# Altium schematic sheet EVSEInterface&ChargerRelay.SchDoc(EVSEInterface&ChargerRelay)
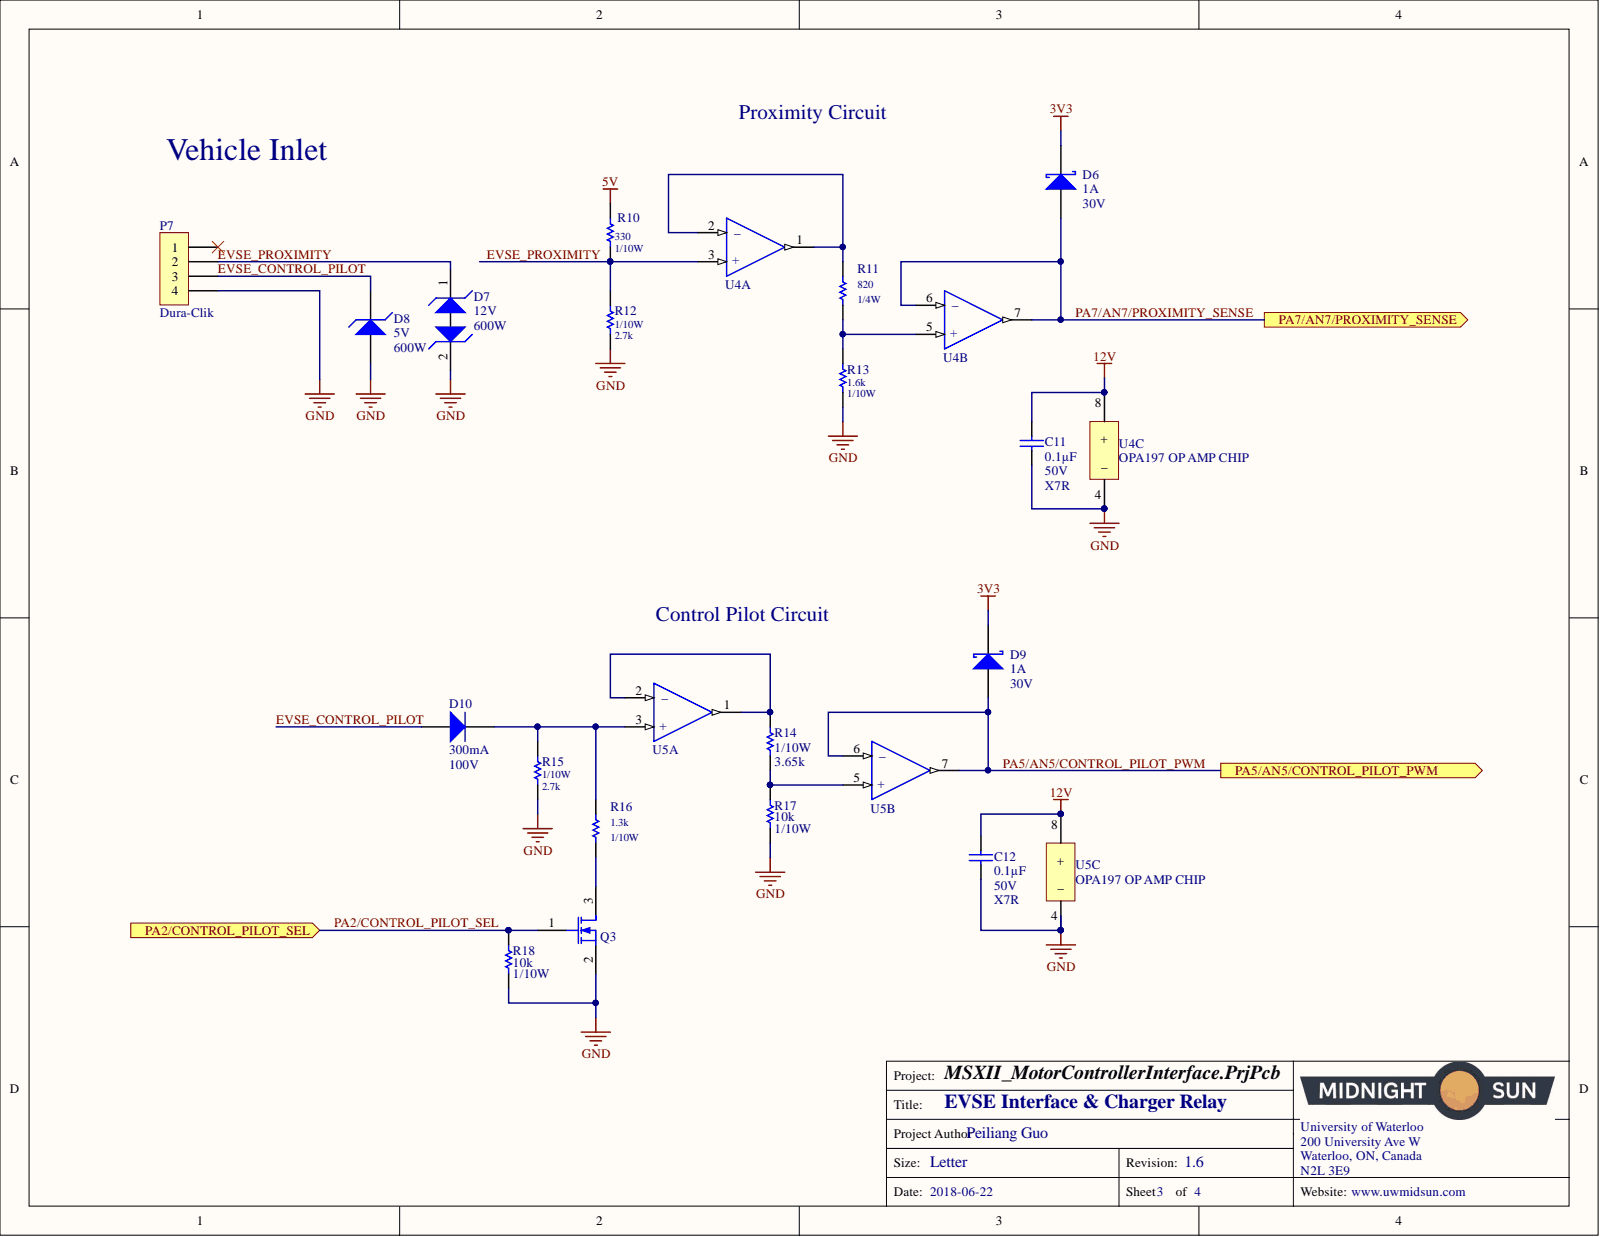
[diagram p0: EVSEInterface&ChargerRelay.SchDoc]

## Parts
C11  Voltage: 50V  Temperature Coefficient: X7R  Capacitance: 0.1µF  [CAP, 0603]  (hidden comment: CAP CER 0.1UF 50V 10% X7R 0603)
C12  Voltage: 50V  Temperature Coefficient: X7R  Capacitance: 0.1µF  [CAP, 0603]  (hidden comment: CAP CER 0.1UF 50V 10% X7R 0603)
D6  Voltage - DC Reverse (Vr) (Max): 30V  Current - Average Rectified (Io): 1A  [DIODE SCHOTTKY 30V 1A POWERDI123]  (hidden comment: DIODE SCHOTTKY 30V 1A POWERDI123)
D7  Voltage - Reverse Standoff (Typ): 12V  Power - Peak Pulse: 600W  [DO-214AA Diode]  (hidden comment: DIODE TVS 12VWM 19.6VC DO-214AA (SMB))
D8  Voltage - Reverse Standoff (Typ): 5V  Power - Peak Pulse: 600W  [DO-214AA Diode]  (hidden comment: DIODE TVS 5VWM 9.1VC DO-214AA (SMB))
D9  Voltage - DC Reverse (Vr) (Max): 30V  Current - Average Rectified (Io): 1A  [DIODE SCHOTTKY 30V 1A POWERDI123]  (hidden comment: DIODE SCHOTTKY 30V 1A POWERDI123)
D10  Max Repetitive Reverse Voltage (Vrrm): 100V  Forward Current: 300mA  [DIODE GEN PURP 100V 300MA SOD123]  (hidden comment: DIODE GEN PURP 100V 300MA SOD123)
P7  Dura-Clik  [CONN, 4POS DURA-CLIK VERT]
Q3  MOSFET N-CH 30V 6.2A 0.9W SOT-23  [MOSFET N-CH 30V 6.2A 0.9W SOT-23]  (value not drawn)
R10  Power: 1/10W  [RES, 0603]  (hidden comment: RES 330 OHM 1% 1/10W 0603)
R11  Power: 1/4W  [RES, 0603]  (hidden comment: RES 820 OHM 5% 1/4W 0603)
R12  Resistance: 2.7k  Power: 1/10W  [RES, 0603]  (hidden comment: RES 2.7K OHM 1% 1/10W 0603)
R13  Resistance: 1.6k  Power Rating: 1/10W  [RES, 0603]  (hidden comment: RES 1.6K OHM 1% 1/10W 0603)
R14  Resistance: 3.65k  Power Rating: 1/10W  [RES, 0603]  (hidden comment: RES 3.65KOHM 0.1% 1/10W 0603)
R15  Resistance: 2.7k  Power: 1/10W  [RES, 0603]  (hidden comment: RES 2.7K OHM 1% 1/10W 0603)
R16  Resistance: 1.3k  Power Rating: 1/10W  [RES, 0603]  (hidden comment: RES 1.3K OHM 1% 1/10W 0603)
R17  Resistance: 10k  Power: 1/10W  [RES, 0603]  (hidden comment: RES 10K OHM 1% 1/10W 0603)
R18  Resistance: 10k  Power: 1/10W  [RES, 0603]  (hidden comment: RES 10K OHM 1% 1/10W 0603)
U4  IC OP AMP DUAL GP RR 10MHZ 8-VSSOP  [IC OP AMP GP RR 10MHZ 8-VSSOP]  (value not drawn)  (parts A,B,C)
U5  IC OP AMP DUAL GP RR 10MHZ 8-VSSOP  [IC OP AMP GP RR 10MHZ 8-VSSOP]  (value not drawn)  (parts A,B,C)

## Ports
PA2/CONTROL_PILOT_SEL, PA5/AN5/CONTROL_PILOT_PWM, PA7/AN7/PROXIMITY_SENSE

## Nets
3V3: D6.2 D9.2
5V: R10.1
12V: C11.1 C12.1 U4.8(+) U5.8(+)
GND: C11.2 C12.2 D7.2 D8.2 P7.4 Q3.2 R12.2 R13.2 R15.2 R17.2 R18.2 U4.4(_) U5.4(_)
EVSE_CONTROL_PILOT: D8.1 D10.1 P7.3
EVSE_PROXIMITY: D7.1 P7.2 R10.2 R12.1 U4.3(+)
PA2/CONTROL_PILOT_SEL: Q3.1 R18.1 ; port PA2/CONTROL_PILOT_SEL
PA5/AN5/CONTROL_PILOT_PWM: D9.1 U5.6 U5.7 ; port PA5/AN5/CONTROL_PILOT_PWM
PA7/AN7/PROXIMITY_SENSE: D6.1 U4.6 U4.7 ; port PA7/AN7/PROXIMITY_SENSE
NetD10_2: D10.2 R15.1 R16.1 U5.3(+)
NetQ3_3: Q3.3 R16.2
NetR11_1: R11.1 U4.1 U4.2
NetR11_2: R11.2 R13.1 U4.5(+)
NetR14_1: R14.1 U5.1 U5.2
NetR14_2: R14.2 R17.1 U5.5(+)

Not connected: P7 1

## Library data of the parts
C11 C12 = CAP CER 0.1UF 50V 10% X7R 0603: 0.10µF ±10% 50V Ceramic Capacitor X7R 0603 (1608 Metric); Manufacturer Part Number 1: 06035C104KAT2A; Manufacturer 1: AVX Corporation; Tolerance: ±10%; Thickness (Max): 0.035" (0.90mm); Supplier Part Number 1: 478-5052-1-ND; Supplier 1: Digi-Key; Size / Dimension: 0.063" L x 0.032" W (1.60mm x 0.81mm); Ratings: -; Part Status: Active; Packaging: Cut Tape (CT); Package / Case: 0603 (1608 Metric); Operating Temperature: -55°C ~ 125°C; Mounting Type: Surface Mount, MLCC; Lead Style: -; Lead Spacing: -; Height - Seated (Max): -; ComponentLink1URL: http://datasheets.avx.com/X7RDielectric.pdf; ComponentLink1Description: http://datasheets.avx.com/X7RDielectric.pdf; Component Kind: Standard; Library Name: Schematic Diagrams.SchLib
D6 D9 = DIODE SCHOTTKY 30V 1A POWERDI123: Diode Schottky 30V 1A Surface Mount PowerDI™ 123; Manufacturer Part Number: DFLS130L-7; Manufacturer: Diodes Incorporated; Voltage - Forward (Vf) (Max) @ If: 310mV @ 1A; Speed: Fast Recovery =< 500ns, > 200mA (Io); Current - Reverse Leakage @ Vr: 1mA @ 30V; Capacitance @ Vr, F: 76pF @ 10V, 1MHz; Supplier Part Number 1: DFLS130LDICT-ND; Supplier Device Package: PowerDI™ 123; Supplier 1: Digi-Key; Stock 1: 68200; RoHS: RoHS Compliant; Pricing 1: 1=0.7, 10=0.599, 100=0.447, 500=0.35126, 1000=0.27142 (CAD); Part Status: Active; Packaging: Cut Tape (CT); Package / Case: POWERDI®123; Operating Temperature - Junction: -40°C ~ 125°C; Mounting Type: Surface Mount; Diode Type: Schottky; ComponentLink1URL: https://www.diodes.com/assets/Datasheets/ds30492.pdf; ComponentLink1Description: https://www.diodes.com/assets/Datasheets/ds30492.pdf; Component Kind: Standard; Category: Discrete Semiconductor Products; Library Name: Schematic Diagrams.SchLib
D7 = DIODE TVS 12VWM 19.6VC DO-214AA (SMB): TVS DIODE 12V 19.6V DO214AA; Manufacturer Part Number: SMBJ12CD-M3/H; Manufacturer: Vishay Semiconductor Diodes Division; Voltage - Breakdown (Min): 13.5V; Type: Zener; Power Line Protection: No; Current - Peak Pulse (10/1000µs): 30.6A; Voltage - Clamping (Max) @ Ipp: 19.6V; Supplier Part Number 1: SMBJ12CD-M3/HGICT-ND; Supplier Device Package: DO-214AA (SMBJ); Supplier 1: Digi-Key; Stock 1: 4469; RoHS: RoHS Compliant; Pricing 1: 1=0.69, 10=0.565, 25=0.516, 100=0.3841, 250=0.34808 (CAD); Part Status: Active; Packaging: Cut Tape (CT); Package / Case: DO-214AA, SMB; Operating Temperature: -55°C ~ 150°C (TJ); Mounting Type: Surface Mount; ComponentLink1URL: http://www.vishay.com/docs/87606/87606.pdf; ComponentLink1Description: http://www.vishay.com/docs/87606/87606.pdf; Component Kind: Standard; Category: Circuit Protection; Capacitance @ Frequency: -; Applications: General Purpose; Library Name: Schematic Diagrams.SchLib
D8 = DIODE TVS 5VWM 9.1VC DO-214AA (SMB): TVS DIODE 5V 9.1V DO214AA; Manufacturer Part Number: SMBJ5.0D-M3/H; Manufacturer: Vishay Semiconductor Diodes Division; Voltage - Breakdown (Min): 6.5V; Type: Zener; Power Line Protection: No; Current - Peak Pulse (10/1000µs): 65.9A; Voltage - Clamping (Max) @ Ipp: 9.1V; Supplier Part Number 1: SMBJ5.0D-M3/HGICT-ND; Supplier Device Package: DO-214AA (SMBJ); Supplier 1: Digi-Key; Stock 1: 6900; RoHS: RoHS Compliant; Pricing 1: 1=0.51, 10=0.416, 25=0.3808, 100=0.2833, 250=0.25668 (CAD); Part Status: Active; Packaging: Cut Tape (CT); Package / Case: DO-214AA, SMB; Operating Temperature: -55°C ~ 150°C (TJ); Mounting Type: Surface Mount; ComponentLink1URL: http://www.vishay.com/docs/87606/87606.pdf; ComponentLink1Description: http://www.vishay.com/docs/87606/87606.pdf; Component Kind: Standard; Category: Circuit Protection; Capacitance @ Frequency: -; Applications: General Purpose; Library Name: Schematic Diagrams.SchLib
D10 = DIODE GEN PURP 100V 300MA SOD123: Diode Standard 100V 300mA (DC) Surface Mount SOD-123; Manufacturer Part Number: 1N4148WQ-7-F; Manufacturer: Diodes Zetex; Reverse Recovery Time: 4ns; Peak Reverse Current: 50uA; Peak Non-Repetitive Surge Current: 2A; Max Power Dissipation: 400mW; Forward Voltage: 1.25V; Element Configuration: Single; Weight: 0.000353oz; Supplier Part Number 1: 1N4148WQ-7-FDICT-ND; Supplier 1: Digi-Key; Stock 1: 229; Recovery Time: 4ns; Pricing 1: 1=0.22, 10=0.196, 100=0.107, 500=0.0658, 1000=0.0449 (USD); Packaging: Tape and Reel; Package Quantity: 3000; Number of Pins: 2; Mount: Surface Mount; Min Operating Temperature: -65°C; Max Surge Current: 2A; Max Operating Temperature: 150°C; Lead Free: Lead Free; Contact Plating: Tin,Matte; ComponentLink3URL: http://www.mouser.com/ds/2/115/ds30086-318161.pdf; ComponentLink3Description: http://www.mouser.com/ds/2/115/ds30086-318161.pdf; ComponentLink2URL: http://www.diodes.com/datasheets/ds30086.pdf; ComponentLink2Description: http://www.diodes.com/datasheets/ds30086.pdf; ComponentLink1URL: http://components.arrow.com/part/dataview/0323_07206809; ComponentLink1Description: http://components.arrow.com/part/dataview/0323_07206809; Component Kind: Standard; Case/Package: SOD-123-2; Library Name: Schematic Diagrams.SchLib
P7 = CONN 4POS DURA-CLIK 0.079": 4 Positions Header Connector 0.079" (2.00mm) Surface Mount Tin; Manufacturer Part Number: 5600200420; Manufacturer: Molex, LLC; Style: Board to Cable/Wire; Shrouding: Shrouded - 4 Wall; Number of Positions Loaded: All; Number of Positions: 4; Mated Stacking Heights: 9.3mm; Voltage Rating: -; Termination: Solder; Supplier Part Number 1: WM10864CT-ND; Supplier 1: Digi-Key; Stock 1: 9367; Row Spacing - Mating: -; RoHS: RoHS Compliant; Pricing 1: 1=2.23, 10=2.022, 25=1.898, 50=1.8156, 100=1.733 (CAD); Pitch - Mating: 0.079" (2.00mm); Part Status: Active; Packaging: Cut Tape (CT); Overall Contact Length: -; Operating Temperature: -; Number of Rows: 1; Mounting Type: Surface Mount; Material Flammability Rating: -; Insulation Material: Polyamide (PA), Nylon; Insulation Height: 0.315" (8.00mm); Insulation Color: Natural; Ingress Protection: -; Features: Solder Retention; Fastening Type: Latch Lock; Current Rating: -; Contact Type: Male Pin; Contact Shape: Square; Contact Material: Copper Alloy; Contact Length - Post: -; Contact Length - Mating: -; Contact Finish Thickness - Post: -; Contact Finish Thickness - Mating: -; Contact Finish - Post: Tin; Contact Finish - Mating: Tin; Connector Type: Header; ComponentLink1URL: http://www.molex.com/pdm_docs/sd/5600200420_sd.pdf; ComponentLink1Description: http://www.molex.com/pdm_docs/sd/5600200420_sd.pdf; Component Kind: Standard; Category: Connectors, Interconnects; Applications: Automotive, Industrial; Library Name: Schematic Diagrams.SchLib
Q3 = MOSFET N-CH 30V 6.2A 0.9W SOT-23: N-Channel 30V 6.2A (Ta) 900mW (Ta) Surface Mount SOT-23; Manufacturer Part Number: DMN3023L-7; Manufacturer: Diodes; Rds On Max: 25mR; Input Capacitance: 873pF; Supplier Part Number 1: DMN3023L-7DICT-ND; Supplier 1: Digi-Key; Stock 1: 38246; Pricing 1: 1=0.47, 10=0.368, 100=0.2529, 500=0.1734, 1000=0.1301 (USD); Packaging: Tape and Reel; Mount: Surface Mount; Min Operating Temperature: -55°C; Max Power Dissipation: 900mW; Max Operating Temperature: 150°C; Drain to Source Voltage (Vdss): 30V; Continuous Drain Current (ID): 6.2A; ComponentLink2URL: http://www.mouser.com/ds/2/115/DMN3023L-959533.pdf; ComponentLink2Description: http://www.mouser.com/ds/2/115/DMN3023L-959533.pdf; ComponentLink1URL: https://www.diodes.com/assets/Datasheets/DMN3023L.pdf; ComponentLink1Description: https://www.diodes.com/assets/Datasheets/DMN3023L.pdf; Component Kind: Standard; Case/Package: TO-236-3; Library Name: Schematic Diagrams.SchLib
R10 = RES 330 OHM 1% 1/10W 0603: 330 Ohms ±1% 0.1W, 1/10W Chip Resistor 0603 (1608 Metric) Automotive AEC-Q200, Moisture Resistant Thick Film; Manufacturer Part Number: 1-2176339-9; Manufacturer: TE Connectivity; Resistance: 330; Supplier Part Number 1: A129682TR-ND; Supplier 1: Digi-Key; Stock 1: 0; Component Kind: Standard; Library Name: Schematic Diagrams.SchLib
R11 = RES 820 OHM 5% 1/4W 0603: RES SMD 820 OHM 5% 1/4W 0603; Manufacturer Part Number 1: ESR03EZPJ821; Manufacturer 1: Rohm Semiconductor; Temperature Coefficient: ±200ppm/°C; Resistance: 820; Tolerance: ±5%; Supplier Part Number 1: RHM820DCT-ND; Supplier Device Package: 0603; Supplier 1: Digi-Key; Size / Dimension: 0.063" L x 0.031" W (1.60mm x 0.80mm); Part Status: Active; Packaging: Cut Tape (CT); Package / Case: 0603 (1608 Metric); Operating Temperature: -55°C ~ 155°C; Height - Seated (Max): 0.022" (0.55mm); Failure Rate: -; Composition: Thick Film; ComponentLink1URL: http://www.rohm.com/web/global/datasheet/ESR01MZPF/esr-e; ComponentLink1Description: http://www.rohm.com/web/global/datasheet/ESR01MZPF/esr-e; Component Kind: Standard; Library Name: Schematic Diagrams.SchLib
R12 R15 = RES 2.7K OHM 1% 1/10W 0603: 2.7 kOhms ±1% 0.1W, 1/10W Chip Resistor 0603 (1608 Metric) Automotive AEC-Q200, Moisture Resistant Thick Film; Manufacturer Part Number: 3-2176339-0; Manufacturer: TE Connectivity; Supplier Part Number 1: A129693TR-ND; Supplier 1: Digi-Key; Stock 1: 0; Component Kind: Standard; Library Name: Schematic Diagrams.SchLib
R13 = RES 1.6K OHM 1% 1/10W 0603: 1.6 kOhms ±1% 0.1W, 1/10W Chip Resistor 0603 (1608 Metric) Moisture Resistant Thick Film; Manufacturer Part Number: RC0603FR-071K6L; Manufacturer: Yageo; Working Voltage: 75V; Voltage Rating (DC): 50V; Temperature Coefficient: 100ppm/°C; Voltage Rating: 50V; Tolerance: 1%; Termination: SMD/SMT; Supplier Part Number 1: 311-1.60KHRCT-ND; Supplier 1: Digi-Key; Stock 1: 189550; Pricing 1: 1=0.1, 10=0.015, 100=0.006, 1000=0.0027, 2500=0.0023 (USD); Packaging: Tape and Reel; Number of Terminations: 2; Min Operating Temperature: -55°C; Military Standard: Not; Max Operating Temperature: 155°C; Length: 1.6mm; Lead Free: Lead Free; Depth: 0.8mm; Composition: Thick Film; ComponentLink4URL: http://www.yageo.com/documents/recent/PYu-RC0603_51_RoHS_L_v5.pdf; ComponentLink4Description: http://www.yageo.com/documents/recent/PYu-RC0603_51_RoHS_L_v5.pdf; ComponentLink3URL: http://www.mouser.com/ds/2/447/RC0603-272842.pdf; ComponentLink3Description: http://www.mouser.com/ds/2/447/RC0603-272842.pdf; ComponentLink2URL: http://www.yageo.com.tw/exep/pages/download/literatures/PYu-R_INT-thick_7.pdf; ComponentLink2Description: http://www.yageo.com.tw/exep/pages/download/literatures/PYu-R_INT-thick_7.pdf; ComponentLink1URL: http://components.arrow.com/part/dataview/0323_00200779; ComponentLink1Description: http://components.arrow.com/part/dataview/0323_00200779; Component Kind: Standard; Case Code (Metric): 1608; Case Code (Imperial): 0603; Case/Package: 0603; Library Name: Schematic Diagrams.SchLib
R14 = RES 3.65KOHM 0.1% 1/10W 0603: Manufacturer Part Number: ERA3AEB3651V; Manufacturer: Panasonic; Voltage Rating (DC): 75V; Voltage Rating: 150V; Temperature Coefficient: 25ppm/°C; Max Power Dissipation: 100mW; Width: 0.8mm; Weight: 7.1E-05oz; Tolerance: 0.1%; Termination: SMD/SMT; Supplier Part Number 1: P3.65KDBCT-ND; Supplier 1: Digi-Key; Stock 1: 140813; Radiation Hardening: No; Pricing 1: 1=0.35, 10=0.3, 100=0.1172, 1000=0.0491, 2500=0.045 (USD); Packaging: Tape and Reel; Package Quantity: 1; Number of Terminations: 2; Number of Pins: 2; Mount: Surface Mount; Min Operating Temperature: -55°C; Military Standard: Not; Max Operating Temperature: 155°C; Length: 1.6mm; Lead Free: Lead Free; Height: 0.45mm; Depth: 0.8mm; Contact Plating: Tin; Composition: Metal Film,Thin Film; ComponentLink6URL: https://datasheet.ciiva.com/41450/001180837-da-01-en-widerstand-era-0603-3k65-0-1-5000st-41450154.pdf; ComponentLink6Description: https://datasheet.ciiva.com/41450/001180837-da-01-en-widerstand-era-0603-3k65-0-1-5000st-41450154.pdf; ComponentLink5URL: https://datasheet.ciiva.com/17213/aoa0000ce26-17213543.pdf; ComponentLink5Description: https://datasheet.ciiva.com/17213/aoa0000ce26-17213543.pdf; ComponentLink4URL: https://datasheet.ciiva.com/7911/485442-7911543.pdf; ComponentLink4Description: https://datasheet.ciiva.com/7911/485442-7911543.pdf; ComponentLink3URL: https://datasheet.ciiva.com/9196/aoa0000ce26-9196230.pdf; ComponentLink3Description: https://datasheet.ciiva.com/9196/aoa0000ce26-9196230.pdf; ComponentLink2URL: https://datasheet.ciiva.com/5974/485442-5974022.pdf; ComponentLink2Description: https://datasheet.ciiva.com/5974/485442-5974022.pdf; ComponentLink1URL: https://datasheet.ciiva.com/11810/0900766b80eb1d8c-11810288.pdf; ComponentLink1Description: https://datasheet.ciiva.com/11810/0900766b80eb1d8c-11810288.pdf; Component Kind: Standard; Case Code (Metric): 1608; Case Code (Imperial): 0603; Case/Package: 0603; Library Name: Schematic Diagrams.SchLib
R16 = RES 1.3K OHM 1% 1/10W 0603: 1.3 kOhms ±1% 0.1W, 1/10W Chip Resistor 0603 (1608 Metric) Moisture Resistant Thick Film; Manufacturer Part Number: RC0603FR-071K3L; Manufacturer: Yageo; Working Voltage: 75V; Voltage Rating (DC): 50V; Temperature Coefficient: 100ppm/°C; Width: 800um; Voltage Rating: 50V; Tolerance: 1%; Termination: SMD/SMT; Supplier Part Number 1: 311-1.30KHRCT-ND; Supplier 1: Digi-Key; Stock 1: 57984; Pricing 1: 1=0.1, 10=0.015, 100=0.006, 1000=0.0027, 2500=0.0023 (USD); Packaging: Tape and Reel; Number of Terminations: 2; Min Operating Temperature: -55°C; Military Standard: Not; Max Operating Temperature: 155°C; Length: 1.6mm; Lead Free: Lead Free; Depth: 0.8mm; Composition: Thick Film; ComponentLink4URL: http://www.yageo.com/documents/recent/PYu-RC0603_51_RoHS_L_v5.pdf; ComponentLink4Description: http://www.yageo.com/documents/recent/PYu-RC0603_51_RoHS_L_v5.pdf; ComponentLink3URL: http://www.yageo.com.tw/exep/pages/download/literatures/PYu-R_INT-thick_7.pdf; ComponentLink3Description: http://www.yageo.com.tw/exep/pages/download/literatures/PYu-R_INT-thick_7.pdf; ComponentLink2URL: http://www.mouser.com/ds/2/447/RC0603-272842.pdf; ComponentLink2Description: http://www.mouser.com/ds/2/447/RC0603-272842.pdf; ComponentLink1URL: http://components.arrow.com/part/dataview/0323_00200771; ComponentLink1Description: http://components.arrow.com/part/dataview/0323_00200771; Component Kind: Standard; Case Code (Metric): 1608; Case Code (Imperial): 0603; Case/Package: 0603; Library Name: Schematic Diagrams.SchLib
R17 R18 = RES 10K OHM 1% 1/10W 0603: RES SMD 10K OHM 1% 1/10W 0603; Manufacturer Part Number 1: RC0603FR-0710KL; Manufacturer 1: Yageo; Temperature Coefficient: ±100ppm/°C; Tolerance: ±1%; Supplier Part Number 1: 311-10.0KHRCT-ND; Supplier Device Package: 0603; Supplier 1: Digi-Key; Size / Dimension: 0.063" L x 0.031" W (1.60mm x 0.80mm); Part Status: Active; Packaging: Cut Tape (CT); Package / Case: 0603 (1608 Metric); Operating Temperature: -55°C ~ 155°C; Height - Seated (Max): 0.022" (0.55mm); Failure Rate: -; Composition: Thick Film; ComponentLink1URL: http://www.yageo.com/documents/recent/PYu-RC_Group_51_RoHS_L_7.pdf; ComponentLink1Description: http://www.yageo.com/documents/recent/PYu-RC_Group_51_RoHS_L_7.pdf; Component Kind: Standard; Library Name: Schematic Diagrams.SchLib
U4 U5 = IC OP AMP DUAL GP RR 10MHZ 8-VSSOP: General Purpose Amplifier 2 Circuit Rail-to-Rail 8-VSSOP; Manufacturer Part Number: OPA2197IDGKR; Manufacturer: Texas Instruments; Slew Rate: 20V/µs; Output Current per Channel: 65mA; Operating Supply Current: 1mA; Number of Circuits: 2; Input Offset Voltage (Vos): 250uV; Input Bias Current: 5pA; Supplier Part Number 1: 296-47349-1-ND; Supplier 1: Digi-Key; Stock 1: 2171; Pricing 1: 1=2.41, 10=2.162, 100=1.7376, 500=1.4276, 1000=1.1829 (USD); Packaging: Tape and Reel; Number of Channels: 2; Mount: Surface Mount; Min Operating Temperature: -40°C; Max Operating Temperature: 125°C; Lead Free: Lead Free; Gain Bandwidth Product: 10MHz; ComponentLink1URL: http://www.ti.com/lit/ds/symlink/opa2197.pdf; ComponentLink1Description: http://www.ti.com/lit/ds/symlink/opa2197.pdf; Component Kind: Standard; Case/Package: VSSOP; Library Name: Schematic Diagrams.SchLib
